# Altium schematic sheet SoC_DDR.SchDoc
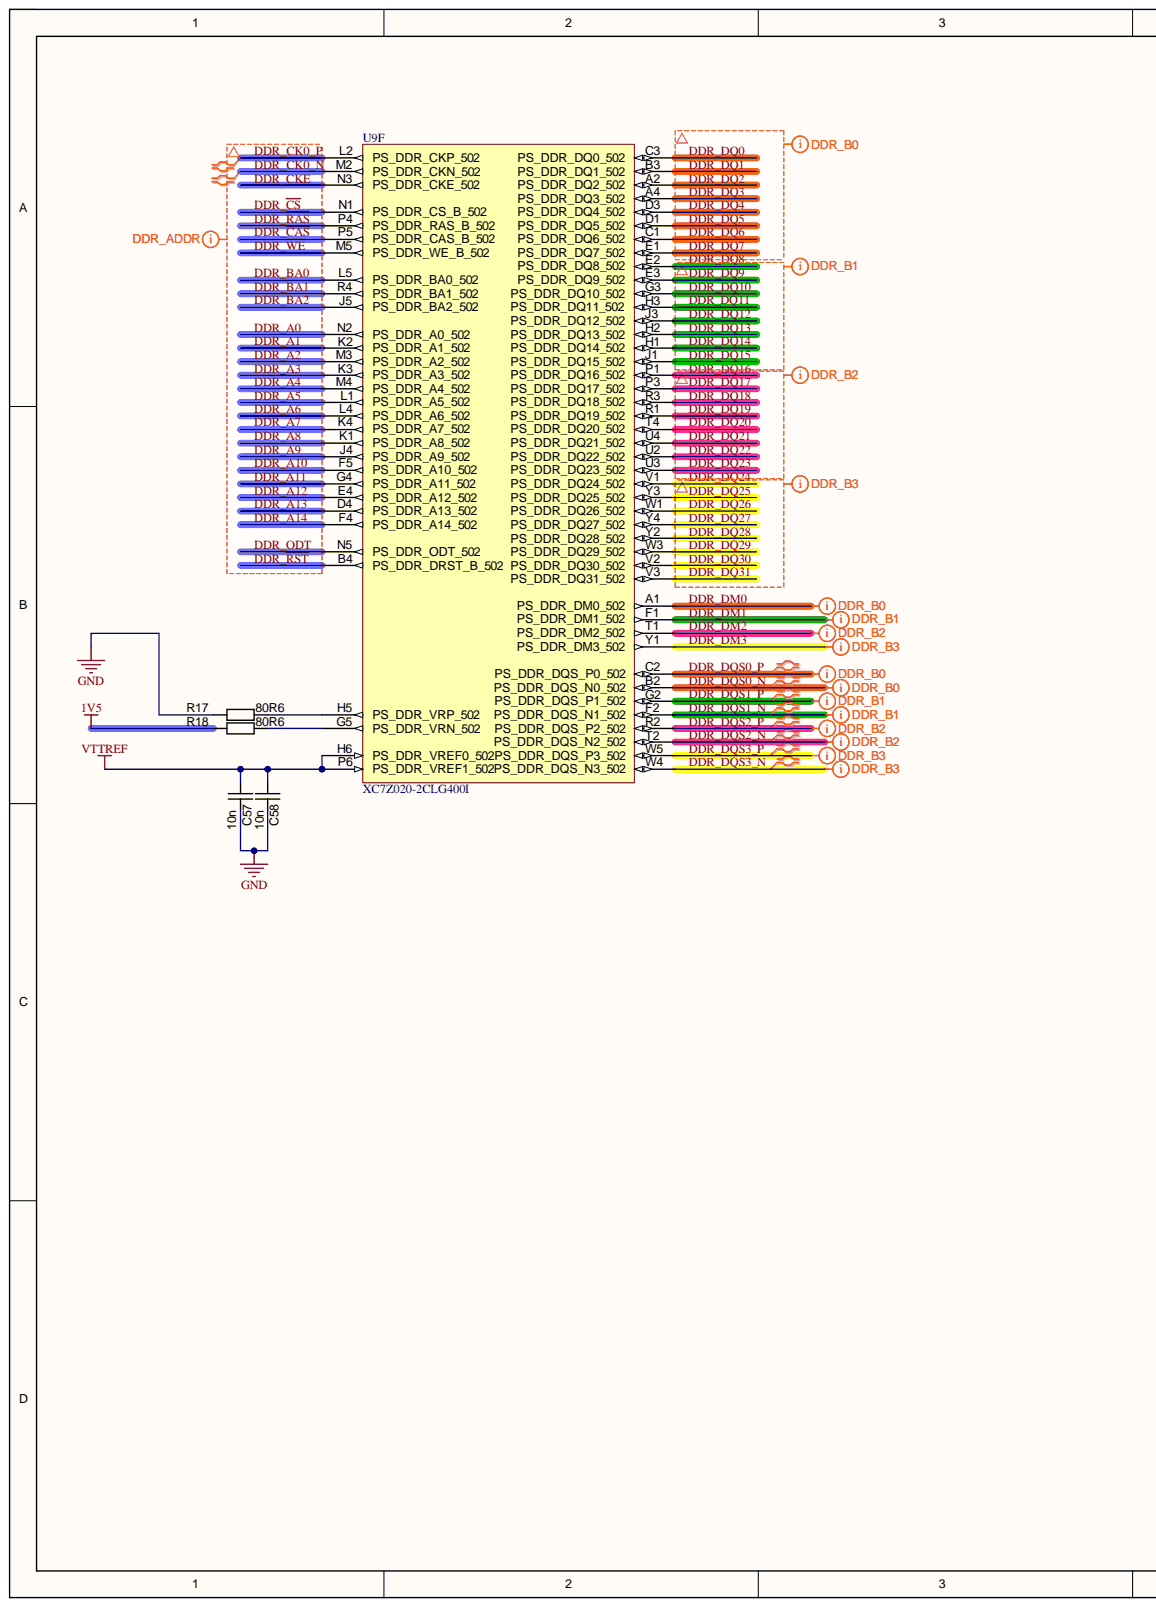
[diagram p0: SoC_DDR.SchDoc, left]
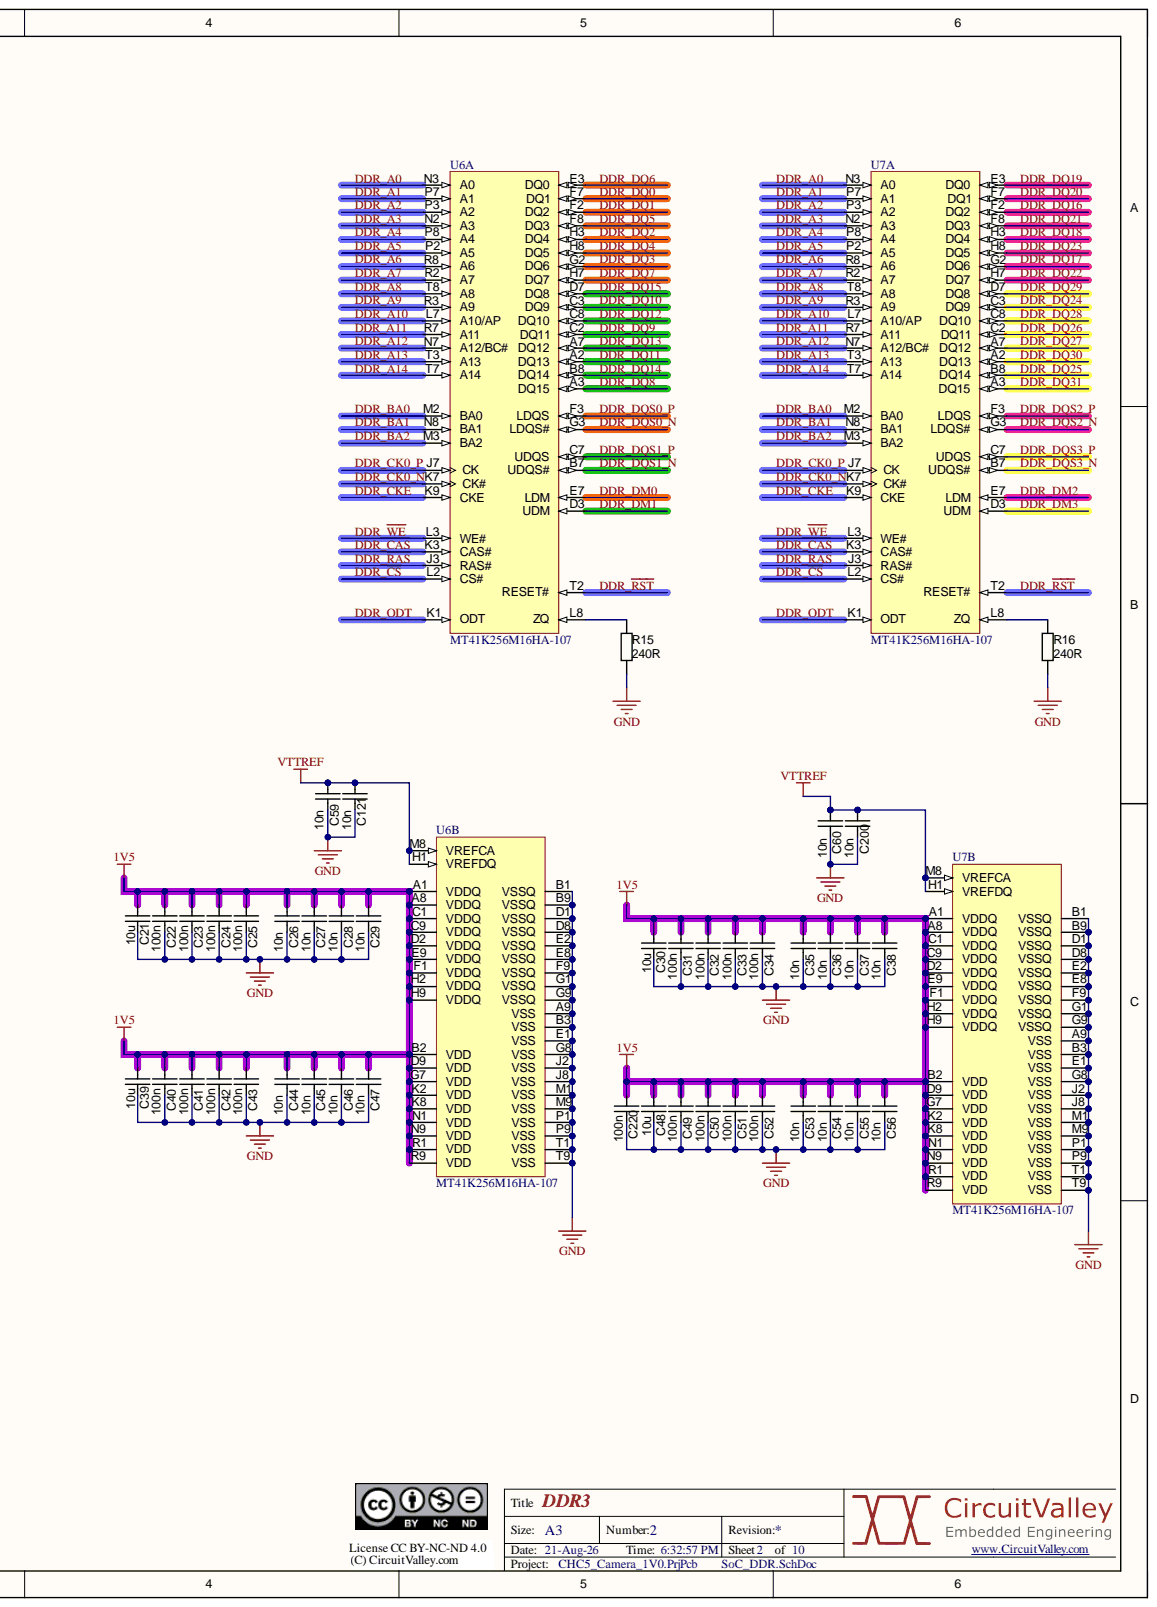
[diagram p1: SoC_DDR.SchDoc, right]

## Parts
C21  value 10u  [CAPC1608N]  (hidden comment: approved sub OK)
C22  100n  [CAPC1005N]
C23  100n  [CAPC1005N]
C24  100n  [CAPC1005N]
C25  100n  [CAPC1005N]
C26  10n  [CAPC1005N]
C27  10n  [CAPC1005N]
C28  10n  [CAPC1005N]
C29  10n  [CAPC1005N]
C30  value 10u  [CAPC1608N]  (hidden comment: approved sub OK)
C31  100n  [CAPC1005N]
C32  100n  [CAPC1005N]
C33  100n  [CAPC1005N]
C34  100n  [CAPC1005N]
C35  10n  [CAPC1005N]
C36  10n  [CAPC1005N]
C37  10n  [CAPC1005N]
C38  10n  [CAPC1005N]
C39  value 10u  [CAPC1608N]  (hidden comment: approved sub OK)
C40  100n  [CAPC1005N]
C41  100n  [CAPC1005N]
C42  100n  [CAPC1005N]
C43  100n  [CAPC1005N]
C44  10n  [CAPC1005N]
C45  10n  [CAPC1005N]
C46  10n  [CAPC1005N]
C47  10n  [CAPC1005N]
C48  value 10u  [CAPC1608N]  (hidden comment: approved sub OK)
C49  100n  [CAPC1005N]
C50  100n  [CAPC1005N]
C51  100n  [CAPC1005N]
C52  100n  [CAPC1005N]
C53  10n  [CAPC1005N]
C54  10n  [CAPC1005N]
C55  10n  [CAPC1005N]
C56  10n  [CAPC1005N]
C57  10n  [CAPC1005N]
C58  10n  [CAPC1005N]
C59  10n  [CAPC1005N]
C60  10n  [CAPC1005N]
C121  10n  [CAPC1005N]
C200  10n  [CAPC1005N]
C220  100n  [CAPC1005N]
R15  value 240R  [RESC1005N]  (hidden comment: Thick Film)
R16  value 240R  [RESC1005N]  (hidden comment: Thick Film)
R17  value 80R6  [RESC1005N]  (hidden comment: Thick Film)
R18  value 80R6  [RESC1005N]  (hidden comment: Thick Film)
U6  MT41K256M16HA-107  [MICT-HA-96]  (parts A,B)
U7  MT41K256M16HA-107  [MICT-HA-96]  (parts A,B)
U9  XC7Z020-2CLG400I  [CLG400]  (part F)

## Nets
1V5: C21.1 C22.2 C23.2 C24.2 C25.2 C26.2 C27.2 C28.2 C29.2 C30.1 C31.2 C32.2 C33.2 C34.2 C35.2 C36.2 C37.2 C38.2 C39.1 C40.2 C41.2 C42.2 C43.2 C44.2 C45.2 C46.2 C47.2 C48.1 C49.2 C50.2 C51.2 C52.2 C53.2 C54.2 C55.2 C56.2 C220.2 R18.1 U6.A1(VDDQ) U6.A8(VDDQ) U6.B2(VDD) U6.C1(VDDQ) U6.C9(VDDQ) U6.D2(VDDQ) U6.D9(VDD) U6.E9(VDDQ) U6.F1(VDDQ) U6.G7(VDD) U6.H2(VDDQ) U6.H9(VDDQ) U6.K2(VDD) U6.K8(VDD) U6.N1(VDD) U6.N9(VDD) U6.R1(VDD) U6.R9(VDD) U7.A1(VDDQ) U7.A8(VDDQ) U7.B2(VDD) U7.C1(VDDQ) U7.C9(VDDQ) U7.D2(VDDQ) U7.D9(VDD) U7.E9(VDDQ) U7.F1(VDDQ) U7.G7(VDD) U7.H2(VDDQ) U7.H9(VDDQ) U7.K2(VDD) U7.K8(VDD) U7.N1(VDD) U7.N9(VDD) U7.R1(VDD) U7.R9(VDD)
GND: C21.2 C22.1 C23.1 C24.1 C25.1 C26.1 C27.1 C28.1 C29.1 C30.2 C31.1 C32.1 C33.1 C34.1 C35.1 C36.1 C37.1 C38.1 C39.2 C40.1 C41.1 C42.1 C43.1 C44.1 C45.1 C46.1 C47.1 C48.2 C49.1 C50.1 C51.1 C52.1 C53.1 C54.1 C55.1 C56.1 C57.1 C58.1 C59.1 C60.1 C121.1 C200.1 C220.1 R15.1 R16.1 R17.1 U6.A9(VSS) U6.B1(VSSQ) U6.B3(VSS) U6.B9(VSSQ) U6.D1(VSSQ) U6.D8(VSSQ) U6.E1(VSS) U6.E2(VSSQ) U6.E8(VSSQ) U6.F9(VSSQ) U6.G1(VSSQ) U6.G8(VSS) U6.G9(VSSQ) U6.J2(VSS) U6.J8(VSS) U6.M1(VSS) U6.M9(VSS) U6.P1(VSS) U6.P9(VSS) U6.T1(VSS) U6.T9(VSS) U7.A9(VSS) U7.B1(VSSQ) U7.B3(VSS) U7.B9(VSSQ) U7.D1(VSSQ) U7.D8(VSSQ) U7.E1(VSS) U7.E2(VSSQ) U7.E8(VSSQ) U7.F9(VSSQ) U7.G1(VSSQ) U7.G8(VSS) U7.G9(VSSQ) U7.J2(VSS) U7.J8(VSS) U7.M1(VSS) U7.M9(VSS) U7.P1(VSS) U7.P9(VSS) U7.T1(VSS) U7.T9(VSS)
VTTREF: C57.2 C58.2 C59.2 C60.2 C121.2 C200.2 U6.H1(VREFDQ) U6.M8(VREFCA) U7.H1(VREFDQ) U7.M8(VREFCA) U9.H6(PS_DDR_VREF0_502) U9.P6(PS_DDR_VREF1_502)
DDR_A0: U6.N3(A0) U7.N3(A0) U9.N2(PS_DDR_A0_502)
DDR_A1: U6.P7(A1) U7.P7(A1) U9.K2(PS_DDR_A1_502)
DDR_A2: U6.P3(A2) U7.P3(A2) U9.M3(PS_DDR_A2_502)
DDR_A3: U6.N2(A3) U7.N2(A3) U9.K3(PS_DDR_A3_502)
DDR_A4: U6.P8(A4) U7.P8(A4) U9.M4(PS_DDR_A4_502)
DDR_A5: U6.P2(A5) U7.P2(A5) U9.L1(PS_DDR_A5_502)
DDR_A6: U6.R8(A6) U7.R8(A6) U9.L4(PS_DDR_A6_502)
DDR_A7: U6.R2(A7) U7.R2(A7) U9.K4(PS_DDR_A7_502)
DDR_A8: U6.T8(A8) U7.T8(A8) U9.K1(PS_DDR_A8_502)
DDR_A9: U6.R3(A9) U7.R3(A9) U9.J4(PS_DDR_A9_502)
DDR_A10: U6.L7(A10/AP) U7.L7(A10/AP) U9.F5(PS_DDR_A10_502)
DDR_A11: U6.R7(A11) U7.R7(A11) U9.G4(PS_DDR_A11_502)
DDR_A12: U6.N7(A12/BC#) U7.N7(A12/BC#) U9.E4(PS_DDR_A12_502)
DDR_A13: U6.T3(A13) U7.T3(A13) U9.D4(PS_DDR_A13_502)
DDR_A14: U6.T7(A14) U7.T7(A14) U9.F4(PS_DDR_A14_502)
DDR_BA0: U6.M2(BA0) U7.M2(BA0) U9.L5(PS_DDR_BA0_502)
DDR_BA1: U6.N8(BA1) U7.N8(BA1) U9.R4(PS_DDR_BA1_502)
DDR_BA2: U6.M3(BA2) U7.M3(BA2) U9.J5(PS_DDR_BA2_502)
DDR_~{CAS}: U6.K3(CAS#) U7.K3(CAS#) U9.P5(PS_DDR_CAS_B_502)
DDR_~{CS}: U6.L2(CS#) U7.L2(CS#) U9.N1(PS_DDR_CS_B_502)
DDR_CK0_N: U6.K7(CK#) U7.K7(CK#) U9.M2(PS_DDR_CKN_502)
DDR_CK0_P: U6.J7(CK) U7.J7(CK) U9.L2(PS_DDR_CKP_502)
DDR_CKE: U6.K9(CKE) U7.K9(CKE) U9.N3(PS_DDR_CKE_502)
DDR_DM0: U6.E7(LDM) U9.A1(PS_DDR_DM0_502)
DDR_DM1: U6.D3(UDM) U9.F1(PS_DDR_DM1_502)
DDR_DM2: U7.E7(LDM) U9.T1(PS_DDR_DM2_502)
DDR_DM3: U7.D3(UDM) U9.Y1(PS_DDR_DM3_502)
DDR_DQ0: U6.F7(DQ1) U9.C3(PS_DDR_DQ0_502)
DDR_DQ1: U6.F2(DQ2) U9.B3(PS_DDR_DQ1_502)
DDR_DQ2: U6.H3(DQ4) U9.A2(PS_DDR_DQ2_502)
DDR_DQ3: U6.G2(DQ6) U9.A4(PS_DDR_DQ3_502)
DDR_DQ4: U6.H8(DQ5) U9.D3(PS_DDR_DQ4_502)
DDR_DQ5: U6.F8(DQ3) U9.D1(PS_DDR_DQ5_502)
DDR_DQ6: U6.E3(DQ0) U9.C1(PS_DDR_DQ6_502)
DDR_DQ7: U6.H7(DQ7) U9.E1(PS_DDR_DQ7_502)
DDR_DQ8: U6.A3(DQ15) U9.E2(PS_DDR_DQ8_502)
DDR_DQ9: U6.C2(DQ11) U9.E3(PS_DDR_DQ9_502)
DDR_DQ10: U6.C3(DQ9) U9.G3(PS_DDR_DQ10_502)
DDR_DQ11: U6.A2(DQ13) U9.H3(PS_DDR_DQ11_502)
DDR_DQ12: U6.C8(DQ10) U9.J3(PS_DDR_DQ12_502)
DDR_DQ13: U6.A7(DQ12) U9.H2(PS_DDR_DQ13_502)
DDR_DQ14: U6.B8(DQ14) U9.H1(PS_DDR_DQ14_502)
DDR_DQ15: U6.D7(DQ8) U9.J1(PS_DDR_DQ15_502)
DDR_DQ16: U7.F2(DQ2) U9.P1(PS_DDR_DQ16_502)
DDR_DQ17: U7.G2(DQ6) U9.P3(PS_DDR_DQ17_502)
DDR_DQ18: U7.H3(DQ4) U9.R3(PS_DDR_DQ18_502)
DDR_DQ19: U7.E3(DQ0) U9.R1(PS_DDR_DQ19_502)
DDR_DQ20: U7.F7(DQ1) U9.T4(PS_DDR_DQ20_502)
DDR_DQ21: U7.F8(DQ3) U9.U4(PS_DDR_DQ21_502)
DDR_DQ22: U7.H7(DQ7) U9.U2(PS_DDR_DQ22_502)
DDR_DQ23: U7.H8(DQ5) U9.U3(PS_DDR_DQ23_502)
DDR_DQ24: U7.C3(DQ9) U9.V1(PS_DDR_DQ24_502)
DDR_DQ25: U7.B8(DQ14) U9.Y3(PS_DDR_DQ25_502)
DDR_DQ26: U7.C2(DQ11) U9.W1(PS_DDR_DQ26_502)
DDR_DQ27: U7.A7(DQ12) U9.Y4(PS_DDR_DQ27_502)
DDR_DQ28: U7.C8(DQ10) U9.Y2(PS_DDR_DQ28_502)
DDR_DQ29: U7.D7(DQ8) U9.W3(PS_DDR_DQ29_502)
DDR_DQ30: U7.A2(DQ13) U9.V2(PS_DDR_DQ30_502)
DDR_DQ31: U7.A3(DQ15) U9.V3(PS_DDR_DQ31_502)
DDR_DQS0_N: U6.G3(LDQS#) U9.B2(PS_DDR_DQS_N0_502)
DDR_DQS0_P: U6.F3(LDQS) U9.C2(PS_DDR_DQS_P0_502)
DDR_DQS1_N: U6.B7(UDQS#) U9.F2(PS_DDR_DQS_N1_502)
DDR_DQS1_P: U6.C7(UDQS) U9.G2(PS_DDR_DQS_P1_502)
DDR_DQS2_N: U7.G3(LDQS#) U9.T2(PS_DDR_DQS_N2_502)
DDR_DQS2_P: U7.F3(LDQS) U9.R2(PS_DDR_DQS_P2_502)
DDR_DQS3_N: U7.B7(UDQS#) U9.W4(PS_DDR_DQS_N3_502)
DDR_DQS3_P: U7.C7(UDQS) U9.W5(PS_DDR_DQS_P3_502)
DDR_ODT: U6.K1(ODT) U7.K1(ODT) U9.N5(PS_DDR_ODT_502)
DDR_~{RAS}: U6.J3(RAS#) U7.J3(RAS#) U9.P4(PS_DDR_RAS_B_502)
DDR_~{RST}: U6.T2(RESET#) U7.T2(RESET#) U9.B4(PS_DDR_DRST_B_502)
DDR_~{WE}: U6.L3(WE#) U7.L3(WE#) U9.M5(PS_DDR_WE_B_502)
NetR15_2: R15.2 U6.L8(ZQ)
NetR16_2: R16.2 U7.L8(ZQ)
NetR17_2: R17.2 U9.H5(PS_DDR_VRP_502)
NetR18_2: R18.2 U9.G5(PS_DDR_VRN_502)

## Library data of the parts
C21 C30 C39 C48 = CAPC_560p_0603_5%: Capacitor SMD Cer 560pF 5% 50V NP0 0603; Manufacturer 1: AVX Corporation; Manufacturer Part Number 1: 06035A561JAT2A; Capacitance: 470pF; Material: ceramic; Ratings: 50V; NP0; Applications: General Purpose; Component Kind: Standard; ComponentLink1Description: http://www.avx.com/docs/Catalogs/ccog.pdf; ComponentLink1URL: http://www.avx.com/docs/Catalogs/ccog.pdf; Height - Seated (Max): -; Lead Spacing: -; Lead Style: -; Library Name: PBL - Capacitors.SCHLIB; Mounting Type: Surface Mount, MLCC; Operating Temperature: -55°C ~ 125°C; Package / Case: 0603 (1608 metric); Size / Dimension: 0.063" L x 0.032" W (1.60mm x 0.81mm); Supplier 1: Digi-Key; Supplier Part Number 1: 478-1184-1-ND; Thickness (Max): 0.035" (0.90mm); Voltage - Rated: 50V
C22-C29 C31-C38 C40-C47 C49-C60 C121 C200 C220 = CAPC_10n_0402_16V_10%: Capacitor SMD Cer 10nF 10% 16V X7R 0402; Manufacturer 1: AVX Corporation; Manufacturer Part Number 1: 0402YC103KAT2A; Ratings: 16V; X7R; Component Kind: Standard; ComponentLink1Description: http://www.avx.com/docs/Catalogs/cx7r.pdf; ComponentLink1URL: http://www.avx.com/docs/Catalogs/cx7r.pdf; ComponentLink2Description: http://www.yageo.com/documents/recent/UPY-GPHC_X7R_6.3V-to-50V_8.pdf; ComponentLink2URL: http://www.yageo.com/documents/recent/UPY-GPHC_X7R_6.3V-to-50V_8.pdf; Library Name: PBL - Capacitors.SCHLIB; Manufacturer 2: Yageo; Manufacturer Part Number 2: CC0402KRX7R7BB103; Operating Temperature: -55°C ~ 125°C; Package / Case: 0402 (1005 Metric); Size / Dimension: 0.039" L x 0.020" W (1.00mm x 0.50mm); Supplier 1: Digi-Key; Supplier 2: Digi-Key; Supplier Part Number 1: 478-1114-1-ND; Supplier Part Number 2: 311-1042-1-ND; Tolerance: ±10%
  C22-C25 C31-C34 C40-C43 C49-C52 C220: Value: 100n
  C26-C29 C35-C38 C44-C47 C53-C60 C121 C200: Value: 10n
R15-R18 = RES_3K3_0402_5%: Resistor SMD chip 3.3k Ohm 0.063W 5% 0402; Manufacturer 1: Yageo; Manufacturer Part Number 1: RC0402JR-073K3L; Ratings: 1/16 W; Component Kind: Standard; ComponentLink1Description: http://www.yageo.com/documents/recent/PYu-RC0402_51_RoHS_L_6.pdf; ComponentLink1URL: http://www.yageo.com/documents/recent/PYu-RC0402_51_RoHS_L_6.pdf; Library Name: PBL - Resistors.SCHLIB; Material: Thick Film; Package / Case: 0402 (1005 metric); Supplier 1: Digi-Key; Supplier Part Number 1: 311-3.3KJRCT-ND; Tolerance: ±5%
U6 U7 = MT41K256M16HA-107: 4Gb DDR3-1866 SDRAM, 256Mb x 16, 1.071ns, 1.35V, 0 to 95 degC, 96-Ball FBGA, Pb-Free; Manufacturer: Micron; CL: CL = 13; Clock Rate: 933 MHz; Op. Temp.: 0C to +95C; Component Kind: Standard; ComponentLink1Description: Manufacturer URL; ComponentLink1URL: http://www.micron.com/; ComponentLink2Description: Datasheet; ComponentLink2URL: http://www.micron.com/~/media/Documents/Products/Data%20Sheet/DRAM/4Gb_1_35V_DDR3L.pdf; ComponentLink3Description: Package Specification; ComponentLink3URL: http://www.micron.com/~/media/Documents/Products/Data%20Sheet/DRAM/4Gb_1_35V_DDR3L.pdf; Cycle Time: 1.071ns; Data Rate: DDR3-1866; DatasheetVersion: Rev. E; Density: 4Gb; Depth: 256Mb; Library Name: Micron Memory DDR3 SDRAM.SchLib; Mounting Technology: Surface Mount; Package: FBGA; PackageDescription: 96-Ball Fine-Pitch Ball Grid Array, 14 x 9 mm, Pitch 0.8 mm; PackageReference: MICT-HA-96; PackageVersion: Rev.E; PartNumber: MT41K256M16HA-107; Pin Count: 96-ball; RoHS: Yes; Voltage: 1.35V; Width: x16
  U6: Signal Integrity: Model Name
U9 = XC7Z020-2CLG400I: 733 MHz Zynq™-7000 AP SoC, 130 PS I/O, 125 FPGA I/O, 400-Ball BGA, Speed Grade 2, Industrial Grade, Pb-Free; Manufacturer: Xilinx; Extensible Block RAM (# 36Kb Blocks): 560 KB (140); Flip Flops: 106400; LUTs: 53200; Speed Grade: -2; Code_JEDEC: MO-275-MMAA-1; Component Kind: Standard; ComponentLink1Description: Datasheet; ComponentLink1URL: http://www.xilinx.com/support/documentation/data_sheets/ds190-Zynq-7000-Overview.pdf; ComponentLink2Description: Package Specification; ComponentLink2URL: http://www.xilinx.com/support/documentation/user_guides/ug865-Zynq-7000-Pkg-Pinout.pdf; ComponentLink3Description: DC and AC Switching Characteristics; ComponentLink3URL: http://www.xilinx.com/support/documentation/data_sheets/ds187-XC7Z010-XC7Z020-Data-Sheet.pdf; ComponentLink4Description: Package File; ComponentLink4URL: http://www.xilinx.com/support/packagefiles/z7packages/xc7z020clg400pkg.txt; ComponentLink5Description: Packaging and Pinout; ComponentLink5URL: http://www.xilinx.com/support/documentation/user_guides/ug865-Zynq-7000-Pkg-Pinout.pdf; ComponentLink6Description: PCB and Layout Guide; ComponentLink6URL: http://www.xilinx.com/support/documentation/user_guides/ug483_7Series_PCB.pdf; DatasheetVersion: v1.2; Generic Part Number: XC7Z020; Library Name: Xilinx Zynq-7000.SchLib; Mounting Technology: Surface Mount; PackageDescription: Wire-Bond Chip-Scale BGA, Body 17 x 17 mm, 0.8 mm Pitch; PackageReference: CLG400; PackageVersion: Rev 1.1; PartNumber: XC7Z020-2CLG400I; RoHS: Pb-Free; Signal Integrity: Model Name
